# Altium schematic sheet Sheet7.SchDoc
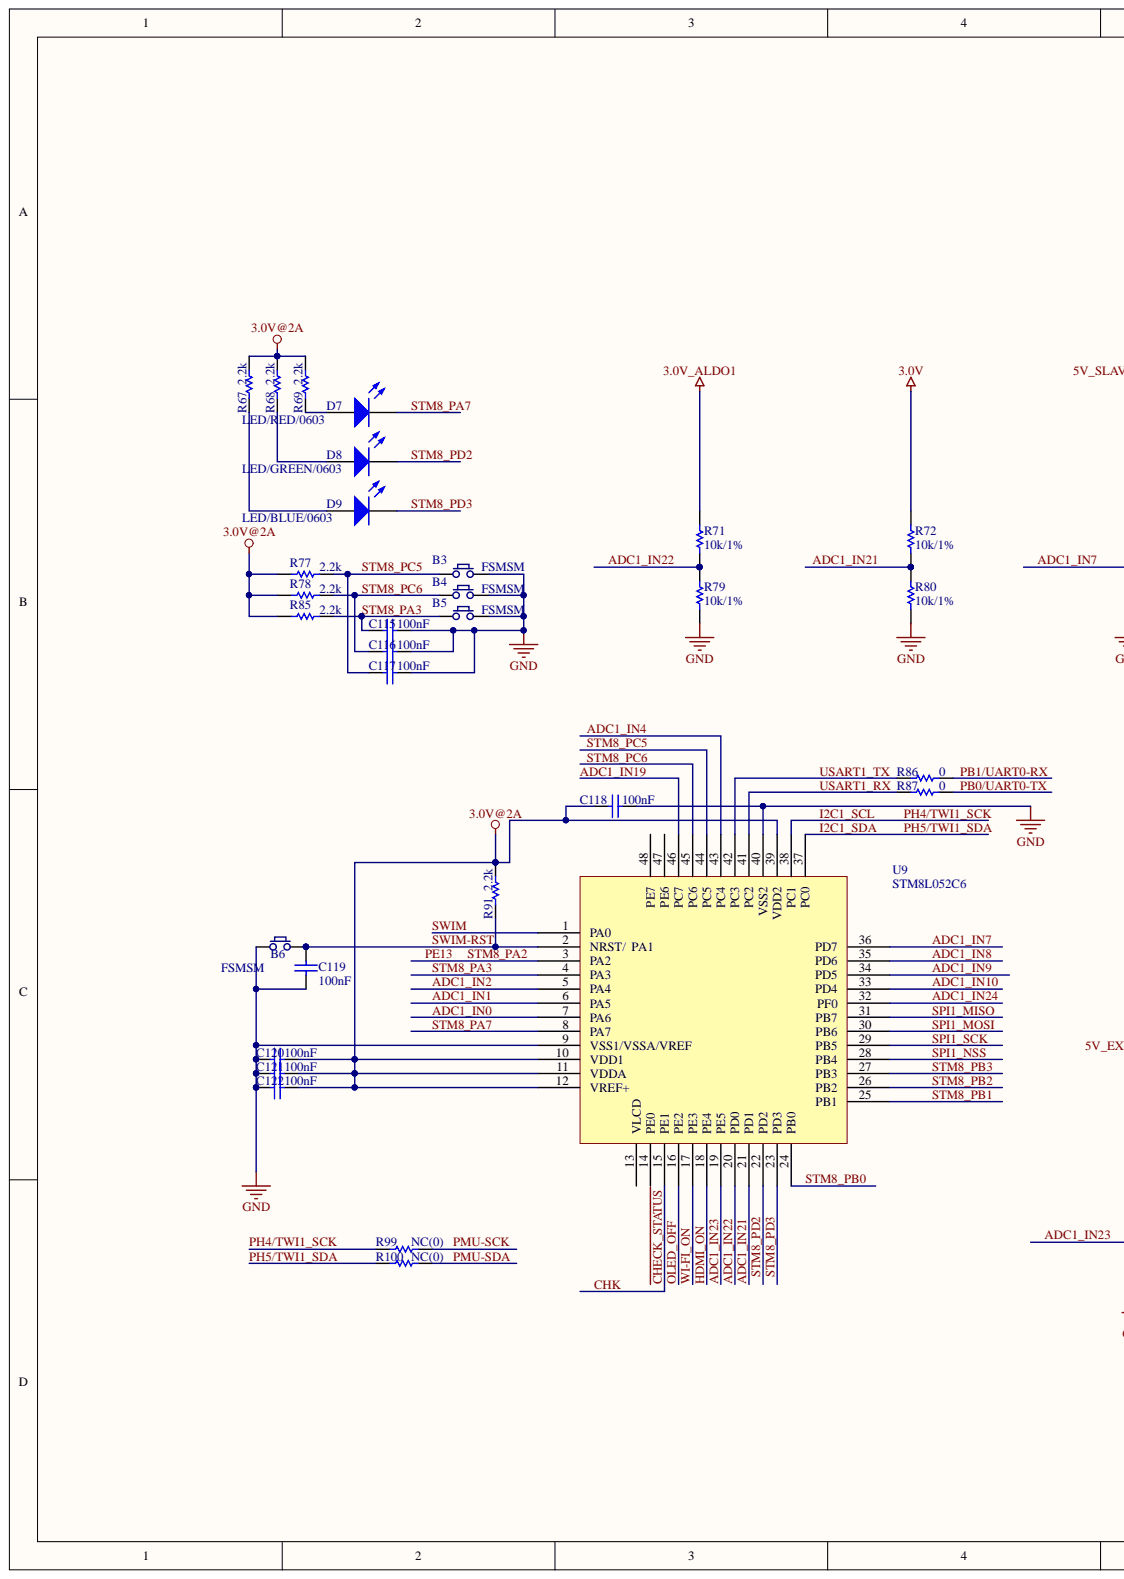
[diagram p0: Sheet7.SchDoc, left]
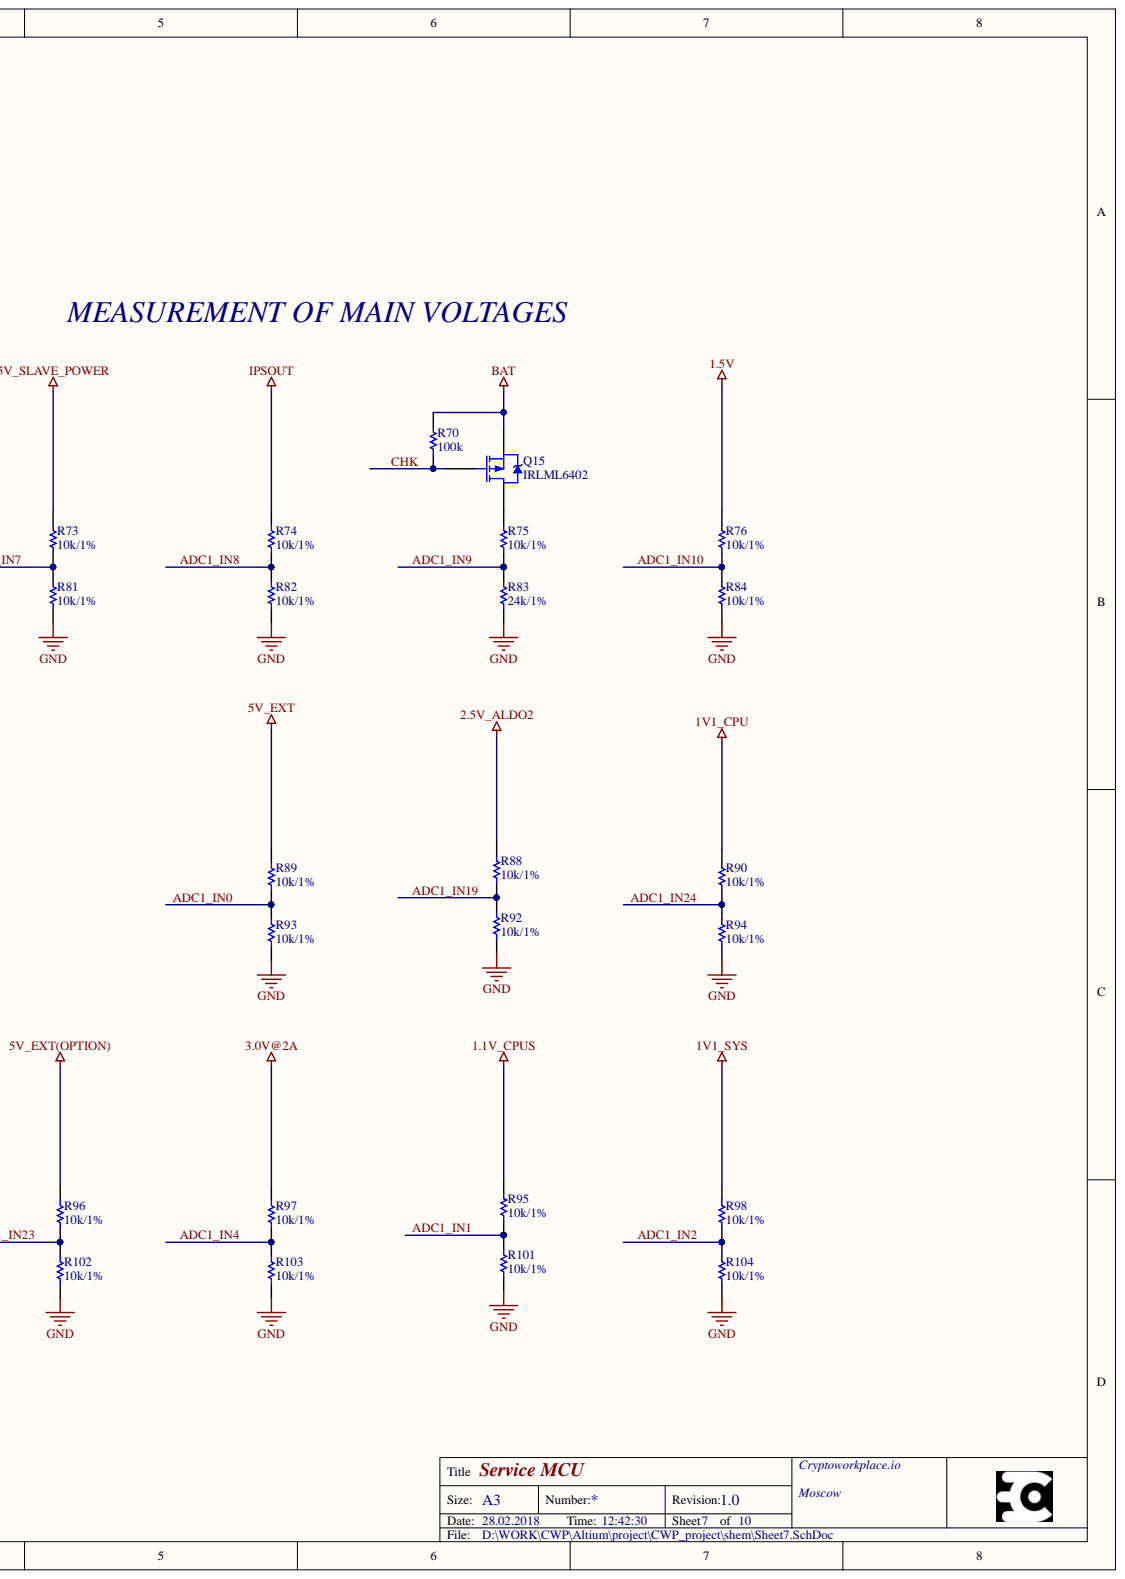
[diagram p1: Sheet7.SchDoc, right]

## Parts
B3  FSMSM  [FSMSM]
B4  FSMSM  [FSMSM]
B5  FSMSM  [FSMSM]
B6  FSMSM  [FSMSM]
C115  value 100nF  [0402(CAPC1005X06N)]  (hidden comment: 0402)
C116  value 100nF  [0402(CAPC1005X06N)]  (hidden comment: 0402)
C117  value 100nF  [0402(CAPC1005X06N)]  (hidden comment: 0402)
C118  value 100nF  [0402(CAPC1005X06N)]  (hidden comment: 0402)
C119  value 100nF  [0402(CAPC1005X06N)]  (hidden comment: 0402)
C120  value 100nF  [0402(CAPC1005X06N)]  (hidden comment: 0402)
C121  value 100nF  [0402(CAPC1005X06N)]  (hidden comment: 0402)
C122  value 100nF  [0402(CAPC1005X06N)]  (hidden comment: 0402)
D7  LED/RED/0603  [LED0603]
D8  LED/GREEN/0603  [LED0603]
D9  LED/BLUE/0603  [LED0603]
Q15  IRLML6402  [IRLML6402]
R67  value 2.2k  [0402(RESC1005X04N)]  (hidden comment: Res1)
R68  value 2.2k  [0402(RESC1005X04N)]  (hidden comment: Res1)
R69  value 2.2k  [0402(RESC1005X04N)]  (hidden comment: Res1)
R70  value 100k  [0402(RESC1005X04N)]  (hidden comment: Res1)
R71  value 10k/1%  [0402(RESC1005X04N)]  (hidden comment: Res1)
R72  value 10k/1%  [0402(RESC1005X04N)]  (hidden comment: Res1)
R73  value 10k/1%  [0402(RESC1005X04N)]  (hidden comment: Res1)
R74  value 10k/1%  [0402(RESC1005X04N)]  (hidden comment: Res1)
R75  value 10k/1%  [0402(RESC1005X04N)]  (hidden comment: Res1)
R76  value 10k/1%  [0402(RESC1005X04N)]  (hidden comment: Res1)
R77  value 2.2k  [0402(RESC1005X04N)]  (hidden comment: Res1)
R78  value 2.2k  [0402(RESC1005X04N)]  (hidden comment: Res1)
R79  value 10k/1%  [0402(RESC1005X04N)]  (hidden comment: Res1)
R80  value 10k/1%  [0402(RESC1005X04N)]  (hidden comment: Res1)
R81  value 10k/1%  [0402(RESC1005X04N)]  (hidden comment: Res1)
R82  value 10k/1%  [0402(RESC1005X04N)]  (hidden comment: Res1)
R83  value 24k/1%  [0402(RESC1005X04N)]  (hidden comment: Res1)
R84  value 10k/1%  [0402(RESC1005X04N)]  (hidden comment: Res1)
R85  value 2.2k  [0402(RESC1005X04N)]  (hidden comment: Res1)
R86  value 0  [0402(RESC1005X04N)]  (hidden comment: Res1)
R87  value 0  [0402(RESC1005X04N)]  (hidden comment: Res1)
R88  value 10k/1%  [0402(RESC1005X04N)]  (hidden comment: Res1)
R89  value 10k/1%  [0402(RESC1005X04N)]  (hidden comment: Res1)
R90  value 10k/1%  [0402(RESC1005X04N)]  (hidden comment: Res1)
R91  value 2.2k  [0402(RESC1005X04N)]  (hidden comment: Res1)
R92  value 10k/1%  [0402(RESC1005X04N)]  (hidden comment: Res1)
R93  value 10k/1%  [0402(RESC1005X04N)]  (hidden comment: Res1)
R94  value 10k/1%  [0402(RESC1005X04N)]  (hidden comment: Res1)
R95  value 10k/1%  [0402(RESC1005X04N)]  (hidden comment: Res1)
R96  value 10k/1%  [0402(RESC1005X04N)]  (hidden comment: Res1)
R97  value 10k/1%  [0402(RESC1005X04N)]  (hidden comment: Res1)
R98  value 10k/1%  [0402(RESC1005X04N)]  (hidden comment: Res1)
R99  value NC(0)  [0402(RESC1005X04N)]  (hidden comment: Res1)
R100  value NC(0)  [0402(RESC1005X04N)]  (hidden comment: Res1)
R101  value 10k/1%  [0402(RESC1005X04N)]  (hidden comment: Res1)
R102  value 10k/1%  [0402(RESC1005X04N)]  (hidden comment: Res1)
R103  value 10k/1%  [0402(RESC1005X04N)]  (hidden comment: Res1)
R104  value 10k/1%  [0402(RESC1005X04N)]  (hidden comment: Res1)
U9  STM8L052C6  [LQFP-48, 7X7]

## Nets
1.1V_CPUS: R95.1
1.5V: R76.1
1V1_CPU: R90.1
1V1_SYS: R98.1
2.5V_ALDO2: R88.1
3.0V: R72.1
3.0V@2A: C118.1 C120.2 C121.2 C122.2 R67.2 R68.2 R69.2 R77.2 R78.2 R85.2 R91.2 R97.1 U9.10(VDD1) U9.11(VDDA) U9.12(VREF+) U9.39(VDD2)
3.0V_ALDO1: R71.1
5V_EXT: R89.1
5V_EXT(OPTION): R96.1
5V_SLAVE_POWER: R73.1
BAT: Q15.3 R70.1
GND: B3.2 B4.2 B5.2 B6.1 C115.2 C116.2 C117.2 C118.2 C119.2 C120.1 C121.1 C122.1 R79.2 R80.2 R81.2 R82.2 R83.2 R84.2 R92.2 R93.2 R94.2 R101.2 R102.2 R103.2 R104.2 U9.9(VSS1/VSSA/VREF) U9.40(VSS2)
IPSOUT: R74.1
~{CHECK_STATUS}: Q15.1 R70.2 U9.15(PE1)
ADC1_IN0: R89.2 R93.1 U9.7(PA6)
ADC1_IN1: R95.2 R101.1 U9.6(PA5)
ADC1_IN2: R98.2 R104.1 U9.5(PA4)
ADC1_IN4: R97.2 R103.1 U9.43(PC4)
ADC1_IN7: R73.2 R81.1 U9.36(PD7)
ADC1_IN8: R74.2 R82.1 U9.35(PD6)
ADC1_IN9: R75.2 R83.1 U9.34(PD5)
ADC1_IN10: R76.2 R84.1 U9.33(PD4)
ADC1_IN19: R88.2 R92.1 U9.46(PC7)
ADC1_IN21: R72.2 R80.1 U9.21(PD1)
ADC1_IN22: R71.2 R79.1 U9.20(PD0)
ADC1_IN23: R96.2 R102.1 U9.19(PE5)
ADC1_IN24: R90.2 R94.1 U9.32(PF0)
HDMI_ON: U9.18(PE4)
I2C1_SCL: R99.1 U9.38(PC1)
I2C1_SDA: R100.1 U9.37(PC0)
OLED_OFF: U9.16(PE2)
PB0/UART0-TX: R87.2
PB1/UART0-RX: R86.2
PE13: U9.3(PA2)
PMU-SCK: R99.2
PMU-SDA: R100.2
SPI1_MISO: U9.31(PB7)
SPI1_MOSI: U9.30(PB6)
SPI1_NSS: U9.28(PB4)
SPI1_SCK: U9.29(PB5)
STM8_PA3: B5.1 C115.1 R85.1 U9.4(PA3)
STM8_PA7: D7.2 U9.8(PA7)
STM8_PB0: U9.24(PB0)
STM8_PB1: U9.25(PB1)
STM8_PB2: U9.26(PB2)
STM8_PB3: U9.27(PB3)
STM8_PC5: B3.1 C117.1 R77.1 U9.44(PC5)
STM8_PC6: B4.1 C116.1 R78.1 U9.45(PC6)
STM8_PD2: D8.2 U9.22(PD2)
STM8_PD3: D9.2 U9.23(PD3)
SWIM: U9.1(PA0)
SWIM-RST: B6.2 C119.1 R91.1 U9.2(NRST/)
USART1_RX: R87.1 U9.41(PC2)
USART1_TX: R86.1 U9.42(PC3)
WI-FI_ON: U9.17(PE3)
NetD7_1: D7.1 R69.1
NetD8_1: D8.1 R68.1
NetD9_1: D9.1 R67.1
NetQ15_2: Q15.2 R75.1

Not connected: U9 13(VLCD) 14(PE0) 47(PE6) 48(PE7)

## Library data of the parts
B3-B6 = DTSM-32N: тактовая кнопка; Component Kind: Standard; Library Name: Button.SchLib
C115-C122 = Cap: Capacitor; Component Kind: Standard; LatestRevisionDate: 17-Jul-2002; LatestRevisionNote: Re-released for DXP Platform.; Library Name: Miscellaneous Devices.IntLib; PackageDescription: Radial Cap, Thru-Hole; 2 Leads; 0.3 in Pin Spacing; PackageReference: RAD-0.3; Published: 8-Jun-2000; Signal Integrity: Cap; Simulation: CAP; Publisher: Altium Limited
D7-D9 = LED0: Typical INFRARED GaAs LED; Component Kind: Standard; LatestRevisionDate: 08-Jul-2005; LatestRevisionNote: Mech Layer 1 removed.; Library Name: Miscellaneous Devices.IntLib; PackageDescription: LED; 2 Leads; PackageReference: LED-0; Published: 8-Jun-2000; Simulation: LED0; Publisher: Altium Limited
Q15 = PMOS-2: P-Channel Power MOSFET; Note: Pin 4 not drawn and electrically connected to Pin 2.; Component Kind: Standard; ComponentLink1Description: Manufacturer Link; ComponentLink1URL: http://www.irf.com/; DatasheetVersion: PD-9.390D; LatestRevisionDate: 17-Jul-2002; LatestRevisionNote: Re-released for DXP Platform.; Library Name: Miscellaneous Devices.IntLib; PackageDescription: TO, Thru-Hole, Vertical, Heatsink Mounted; 3 In-Line Leads; Pitch 2.54 mm; PackageReference: TO-220AB; PackageVersion: Feb-1997; Published: 8-Jun-2000; Simulation: IRF9510; Publisher: Altium Limited
R67-R104 = Res1: Resistor; Component Kind: Standard; LatestRevisionDate: 17-Jul-2002; LatestRevisionNote: Re-released for DXP Platform.; Library Name: Miscellaneous Devices.IntLib; PackageDescription: Axial Device, Thru-Hole; 2 Leads; 0.3 in Pin Spacing; PackageReference: AXIAL-0.3; Published: 8-Jun-2000; Signal Integrity: Res; Simulation: RESISTOR; Publisher: Altium Limited
U9 = STM8L052C6: 8-bit ultra-low-power MCU, 32-KB Flash, 256-byte data  EEPROM, RTC, LCD, timers, USART, I2C, SPI, ADC; Component Kind: Standard; Library Name: STM8.SchLib
